# Altium schematic sheet 08-MCU.SchDoc
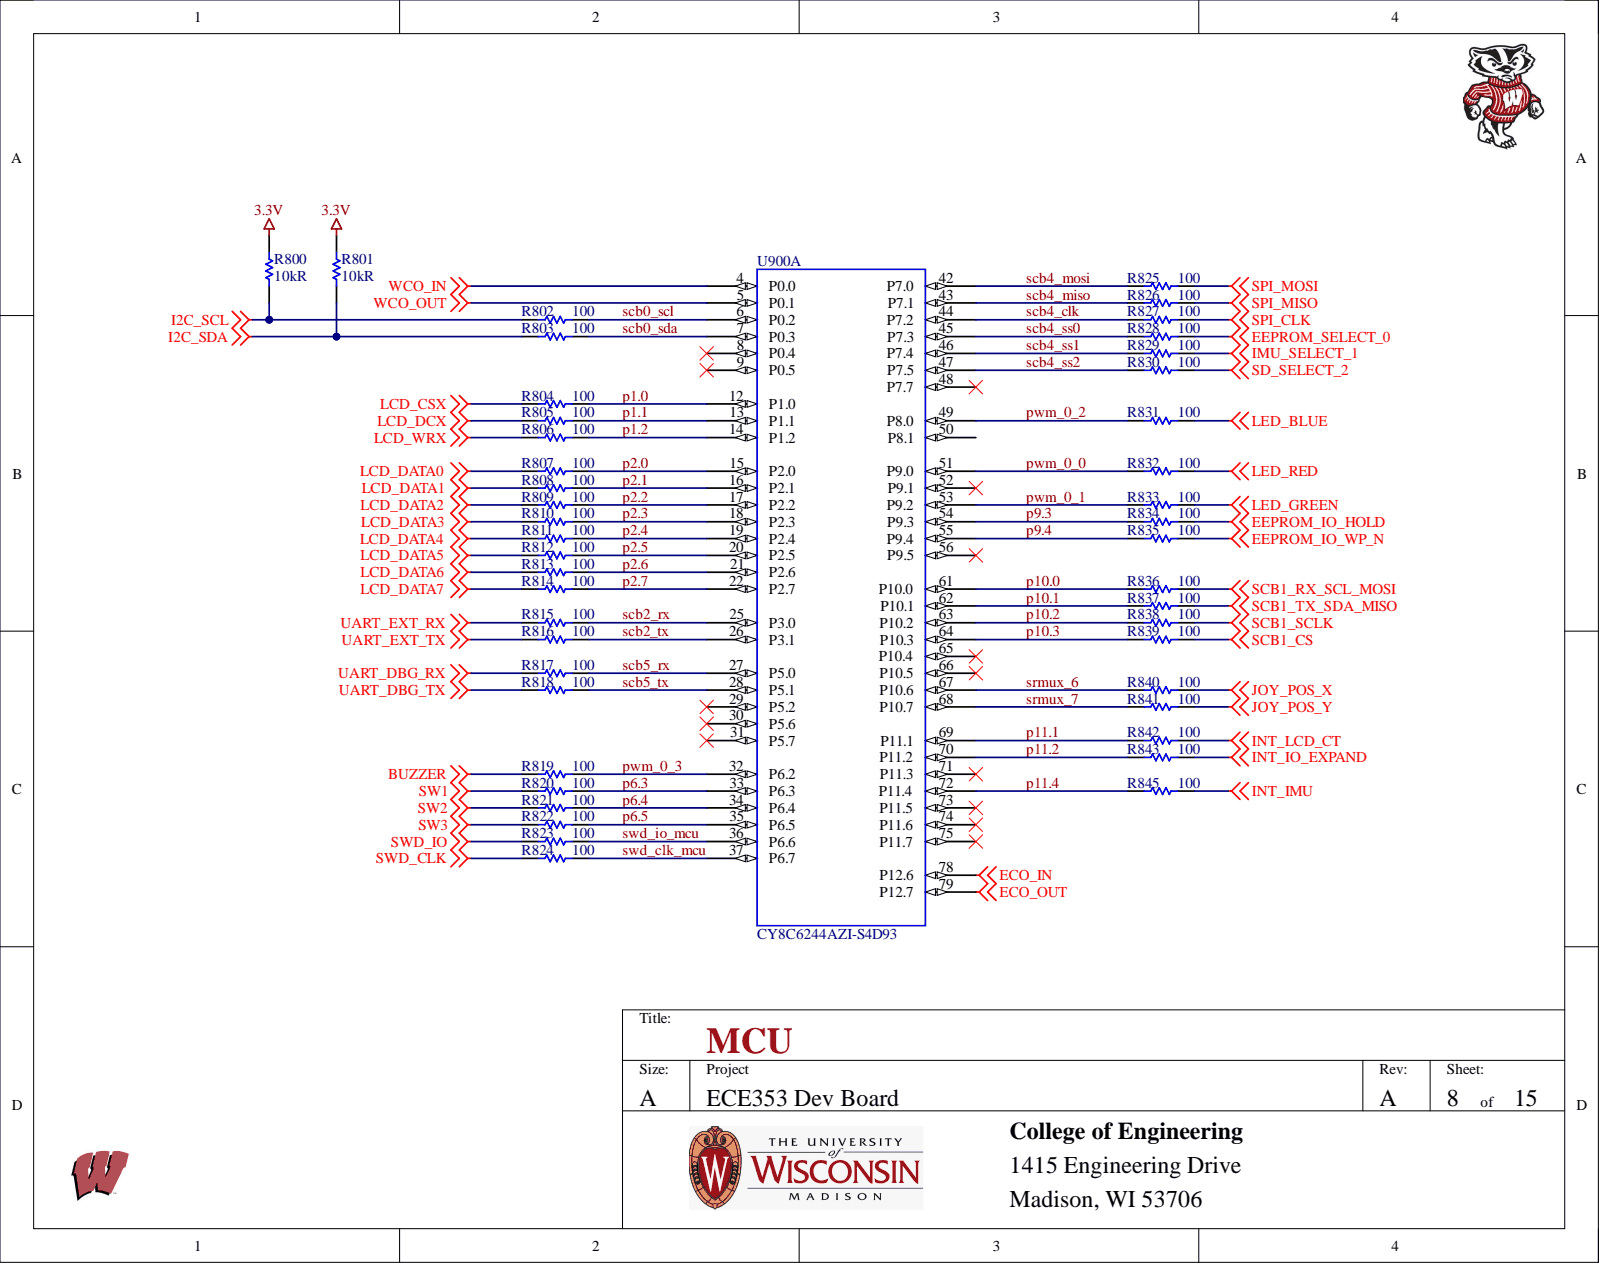
[diagram p0: 08-MCU.SchDoc]

## Parts
R800  Resistance: 10kR  [RESC2013X60X35NL10T20]  (hidden comment: RC0805FR-0710KL)
R801  Resistance: 10kR  [RESC2013X60X35NL10T20]  (hidden comment: RC0805FR-0710KL)
R802  RC0805FR-07100RL  [RESC2013X60X35ML10T20]  (value not drawn)
R803  RC0805FR-07100RL  [RESC2013X60X35ML10T20]  (value not drawn)
R804  RC0805FR-07100RL  [RESC2013X60X35ML10T20]  (value not drawn)
R805  RC0805FR-07100RL  [RESC2013X60X35ML10T20]  (value not drawn)
R806  RC0805FR-07100RL  [RESC2013X60X35ML10T20]  (value not drawn)
R807  RC0805FR-07100RL  [RESC2013X60X35ML10T20]  (value not drawn)
R808  RC0805FR-07100RL  [RESC2013X60X35ML10T20]  (value not drawn)
R809  RC0805FR-07100RL  [RESC2013X60X35ML10T20]  (value not drawn)
R810  RC0805FR-07100RL  [RESC2013X60X35ML10T20]  (value not drawn)
R811  RC0805FR-07100RL  [RESC2013X60X35ML10T20]  (value not drawn)
R812  RC0805FR-07100RL  [RESC2013X60X35ML10T20]  (value not drawn)
R813  RC0805FR-07100RL  [RESC2013X60X35ML10T20]  (value not drawn)
R814  RC0805FR-07100RL  [RESC2013X60X35ML10T20]  (value not drawn)
R815  RC0805FR-07100RL  [RESC2013X60X35ML10T20]  (value not drawn)
R816  RC0805FR-07100RL  [RESC2013X60X35ML10T20]  (value not drawn)
R817  RC0805FR-07100RL  [RESC2013X60X35ML10T20]  (value not drawn)
R818  RC0805FR-07100RL  [RESC2013X60X35ML10T20]  (value not drawn)
R819  RC0805FR-07100RL  [RESC2013X60X35ML10T20]  (value not drawn)
R820  RC0805FR-07100RL  [RESC2013X60X35ML10T20]  (value not drawn)
R821  RC0805FR-07100RL  [RESC2013X60X35ML10T20]  (value not drawn)
R822  RC0805FR-07100RL  [RESC2013X60X35ML10T20]  (value not drawn)
R823  RC0805FR-07100RL  [RESC2013X60X35ML10T20]  (value not drawn)
R824  RC0805FR-07100RL  [RESC2013X60X35ML10T20]  (value not drawn)
R825  RC0805FR-07100RL  [RESC2013X60X35ML10T20]  (value not drawn)
R826  RC0805FR-07100RL  [RESC2013X60X35ML10T20]  (value not drawn)
R827  RC0805FR-07100RL  [RESC2013X60X35ML10T20]  (value not drawn)
R828  RC0805FR-07100RL  [RESC2013X60X35ML10T20]  (value not drawn)
R829  RC0805FR-07100RL  [RESC2013X60X35ML10T20]  (value not drawn)
R830  RC0805FR-07100RL  [RESC2013X60X35ML10T20]  (value not drawn)
R831  RC0805FR-07100RL  [RESC2013X60X35ML10T20]  (value not drawn)
R832  RC0805FR-07100RL  [RESC2013X60X35ML10T20]  (value not drawn)
R833  RC0805FR-07100RL  [RESC2013X60X35ML10T20]  (value not drawn)
R834  RC0805FR-07100RL  [RESC2013X60X35ML10T20]  (value not drawn)
R835  RC0805FR-07100RL  [RESC2013X60X35ML10T20]  (value not drawn)
R836  RC0805FR-07100RL  [RESC2013X60X35ML10T20]  (value not drawn)
R837  RC0805FR-07100RL  [RESC2013X60X35ML10T20]  (value not drawn)
R838  RC0805FR-07100RL  [RESC2013X60X35ML10T20]  (value not drawn)
R839  RC0805FR-07100RL  [RESC2013X60X35ML10T20]  (value not drawn)
R840  RC0805FR-07100RL  [RESC2013X60X35ML10T20]  (value not drawn)
R841  RC0805FR-07100RL  [RESC2013X60X35ML10T20]  (value not drawn)
R842  RC0805FR-07100RL  [RESC2013X60X35ML10T20]  (value not drawn)
R843  RC0805FR-07100RL  [RESC2013X60X35ML10T20]  (value not drawn)
R845  RC0805FR-07100RL  [RESC2013X60X35ML10T20]  (value not drawn)
U900  CY8C6244AZI-S4D93  [CY8C6144AZI-S4F93]  (part A)

## Nets
3.3V: R800.1 R801.1
BUZZER: R819.1
ECO_IN: U900.78(P12.6)
ECO_OUT: U900.79(P12.7)
EEPROM_IO_HOLD: R834.2
EEPROM_IO_WP_N: R835.2
EEPROM_SELECT_0: R828.2
I2C_SCL: R800.2 R802.1
I2C_SDA: R801.2 R803.1
IMU_SELECT_1: R829.2
INT_IMU: R845.2
INT_IO_EXPAND: R843.2
INT_LCD_CT: R842.2
JOY_POS_X: R840.2
JOY_POS_Y: R841.2
LCD_CSX: R804.1
LCD_DATA0: R807.1
LCD_DATA1: R808.1
LCD_DATA2: R809.1
LCD_DATA3: R810.1
LCD_DATA4: R811.1
LCD_DATA5: R812.1
LCD_DATA6: R813.1
LCD_DATA7: R814.1
LCD_DCX: R805.1
LCD_WRX: R806.1
LED_BLUE: R831.2
LED_GREEN: R833.2
LED_RED: R832.2
SD_SELECT_2: R830.2
SPI_CLK: R827.2
SPI_MISO: R826.2
SPI_MOSI: R825.2
SW1: R820.1
SW2: R821.1
SW3: R822.1
SWD_CLK: R824.1
SWD_IO: R823.1
UART_DBG_RX: R817.1
UART_DBG_TX: R818.1
UART_EXT_RX: R815.1
UART_EXT_TX: R816.1
WCO_IN: U900.4(P0.0)
WCO_OUT: U900.5(P0.1)
p1.0: R804.2 U900.12(P1.0)
p1.1: R805.2 U900.13(P1.1)
p1.2: R806.2 U900.14(P1.2)
p2.0: R807.2 U900.15(P2.0)
p2.1: R808.2 U900.16(P2.1)
p2.2: R809.2 U900.17(P2.2)
p2.3: R810.2 U900.18(P2.3)
p2.4: R811.2 U900.19(P2.4)
p2.5: R812.2 U900.20(P2.5)
p2.6: R813.2 U900.21(P2.6)
p2.7: R814.2 U900.22(P2.7)
p6.3: R820.2 U900.33(P6.3)
p6.4: R821.2 U900.34(P6.4)
p6.5: R822.2 U900.35(P6.5)
p9.3: R834.1 U900.54(P9.3)
p9.4: R835.1 U900.55(P9.4)
p10.0: R836.1 U900.61(P10.0)
p10.1: R837.1 U900.62(P10.1)
p10.2: R838.1 U900.63(P10.2)
p10.3: R839.1 U900.64(P10.3)
p11.1: R842.1 U900.69(P11.1)
p11.2: R843.1 U900.70(P11.2)
p11.4: R845.1 U900.72(P11.4)
pwm_0_0: R832.1 U900.51(P9.0)
pwm_0_1: R833.1 U900.53(P9.2)
pwm_0_2: R831.1 U900.49(P8.0)
pwm_0_3: R819.2 U900.32(P6.2)
scb0_scl: R802.2 R836.2 R837.2 R838.2 R839.2 U900.6(P0.2)
scb0_sda: R803.2 U900.7(P0.3)
scb2_rx: R815.2 U900.25(P3.0)
scb2_tx: R816.2 U900.26(P3.1)
scb4_clk: R827.1 U900.44(P7.2)
scb4_miso: R826.1 U900.43(P7.1)
scb4_mosi: R825.1 U900.42(P7.0)
scb4_ss0: R828.1 U900.45(P7.3)
scb4_ss1: R829.1 U900.46(P7.4)
scb4_ss2: R830.1 U900.47(P7.5)
scb5_rx: R817.2 U900.27(P5.0)
scb5_tx: R818.2 U900.28(P5.1)
srmux_6: R840.1 U900.67(P10.6)
srmux_7: R841.1 U900.68(P10.7)
swd_clk_mcu: R824.2 U900.37(P6.7)
swd_io_mcu: R823.2 U900.36(P6.6)

Not connected: U900 8(P0.4) 9(P0.5) 29(P5.2) 30(P5.6) 31(P5.7) 48(P7.7) 50(P8.1) 52(P9.1) 56(P9.5) 65(P10.4) 66(P10.5) 71(P11.3) 73(P11.5) 74(P11.6) 75(P11.7)

## Library data of the parts
R800 R801 = CMP-1659-00013-4: Chip Resistor, 10 KOhm, +/- 1%, 0.125 W, -55 to 155 degC, 0805 (2012 Metric), RoHS, Tape and Reel; Manufacturer: Yageo; Max Power Dissipation: 125mW; Temperature Coefficient: 100ppm/°C; Voltage Rating: 150 V; Case Code (Imperial): 0805; Case Code (Metric): 2012; Case/Package: 0805; Category: Chip SMD Resistors; Component Kind: Standard; ComponentLink1Description: Manufacturer URL; ComponentLink1URL: http://www.yageo.com/; ComponentLink2Description: Datasheet; ComponentLink2URL: http://www.yageo.com/documents/recent/PYu-RC_Group_51_RoHS_L_04.pdf; Construction: Rectangular; DatasheetVersion: Rev. 4, 02/2016; Depth: 1.25mm; Features: Moisture Resistant; Height: 0.5 mm; Lead Free: Lead Free; Length: 2 mm; Library Name: Altium Content Vault; Manufacturer 1: Yageo; Manufacturer Part Number 1: RC0805FR-0710KL; Max Operating Temperature: 155 degC; Military Standard: Not; Min Operating Temperature: -55 degC; Mount: Surface Mount; Mounting Technology: Surface Mount; Number of Terminations: 2; Package Quantity: 5000; PackageDescription: 2-Pin Surface Mounted Device, Body 2 x 1.25 mm; PackageReference: 0805; Packaging: Tape and Reel; Pins: 2; Power Rating: 125mW; Pricing 1: 1=0.10000, 10=0.03700, 100=0.01520, 1000=0.00682, 2500=0.00592 (USD); REACH SVHC: No SVHC; Resistance Tolerance: 1%; Resistor Type: Thick Film; RoHS: Yes; RoHS Compliant: Yes; RoHSCompliant: true; Series: RC; Size Code: 0805; Stock 1: 12202331; Supplier 1: Digi-Key; Supplier Part Number 1: 311-10.0KCRCT-ND; Technology: THICK FILM; Termination: SMD/SMT; Tolerance: 1%; Width: 0.049inch
R802-R843 R845 = CMP-2100-03669-1: Chip Resistor, 100 Ohm, +/-1%, 0.125 W, -55 to 155 degC, 0805 (2012 Metric), RoHS, Tape and Reel; Manufacturer: Yageo; Application(s): HIGH RELIABILITY; Max Power Dissipation: 125mW; Resistance: 100; Temperature Coefficient: 100ppm/degreesC; Tolerance: 1%; Voltage Rating: 150V; Case Code (Imperial): 0805; Case Code (Metric): 2012; Case/Package: 0805; Category: Chip SMD Resistors; Component Kind: Standard; ComponentLink1Description: https://datasheet.ciiva.com/7941/1697719-7941680.pdf?src-supplier=Element14; ComponentLink1URL: https://datasheet.ciiva.com/7941/1697719-7941680.pdf?src-supplier=Element14; ComponentLink2Description: https://datasheet.ciiva.com/11808/0900766b8106beab-11808877.pdf?src-supplier=Rs; ComponentLink2URL: https://datasheet.ciiva.com/11808/0900766b8106beab-11808877.pdf?src-supplier=Rs; ComponentLink3Description: https://datasheet.ciiva.com/7941/1686128-7941679.pdf?src-supplier=Element14; ComponentLink3URL: https://datasheet.ciiva.com/7941/1686128-7941679.pdf?src-supplier=Element14; ComponentLink4Description: https://datasheet.ciiva.com/7660/38627-7660797.pdf?src-supplier=Element14; ComponentLink4URL: https://datasheet.ciiva.com/7660/38627-7660797.pdf?src-supplier=Element14; ComponentLink5Description: https://datasheet.ciiva.com/22747/444164-22747535.pdf?src-supplier=Element14; ComponentLink5URL: https://datasheet.ciiva.com/22747/444164-22747535.pdf?src-supplier=Element14; ComponentLink6Description: https://datasheet.ciiva.com/46447/444164-46447562.pdf?src-supplier=Element14; ComponentLink6URL: https://datasheet.ciiva.com/46447/444164-46447562.pdf?src-supplier=Element14; ComponentLink7Description: https://datasheet.ciiva.com/2456/pyu-r-int-thick-7-2456988.pdf?src-supplier=Digi-Key; ComponentLink7URL: https://datasheet.ciiva.com/2456/pyu-r-int-thick-7-2456988.pdf?src-supplier=Digi-Key; ComponentLink8Description: https://datasheet.ciiva.com/2456/pyu-r-int-thick-7-2456989.pdf?src-supplier=Digi-Key; ComponentLink8URL: https://datasheet.ciiva.com/2456/pyu-r-int-thick-7-2456989.pdf?src-supplier=Digi-Key; ComponentLink9Description: https://datasheet.ciiva.com/2456/pyu-r-int-thick-7-2456987.pdf?src-supplier=Digi-Key; ComponentLink9URL: https://datasheet.ciiva.com/2456/pyu-r-int-thick-7-2456987.pdf?src-supplier=Digi-Key; ComponentLink10Description: https://datasheet.ciiva.com/17082/rc0805-17082697.pdf?src-supplier=Future+Electronics; ComponentLink10URL: https://datasheet.ciiva.com/17082/rc0805-17082697.pdf?src-supplier=Future+Electronics; Composition: ThickFilm; Datasheet URL: http://www.yageo.com/NewPortal/yageodocoutput?fileName=/pdf/R-Chip/PYu-RC_Group_51_RoHS_L_5.pdf; Datasheet Version: Rev. 5, 10/2016; Depth: 1.25mm; Features: Moisture Resistant; Height: 0.024inch; Lead Free: LeadFree; Lead Pitch: 0.4mm; Length: 2mm; Library Name: Altium Content Vault; Manufacturer 1: Yageo; Manufacturer Part Number 1: RC0805FR-07100RL; Manufacturer URL: http://www.yageo.com/; Max Operating Temperature: 155degC; Military Standard: Not; Min Operating Temperature: -55degC; Number of Pins: 2; Number of Terminations: 2; Package Description: 2-Pin Surface Mounted Device, Body 2 x 1.25 mm; Package Quantity: 1; Package Reference: 0805; Packaging: TapeandReel; Power Rating: 125mW; Pricing 1: 1=0.10000, 10=0.03300, 100=0.01330, 1000=0.00600, 2500=0.00520 (USD); REACH SVHC: Unknown; Resistor Type: Thick Film; RoHS: Yes; RoHS Compliant: Yes; RoHSCompliant: true; Series: RC; Stock 1: 3383515; Supplier 1: Digi-Key; Supplier Part Number 1: 311-100CRCT-ND; Technology: THICK FILM; Termination: SMD/SMT; Type: General Purpose; Voltage Rating (DC): 150V; Weight: 0.000917oz; Width: 0.049inch
U900 = CY8C6144AZI-S4F93: Mcu, 32 Bit, 150MHZ, TQFP-80;; Manufacturer 1: Infineon; Category: Microcontrollers; Component Kind: Standard; Library Name: ece353-2022.SchLib; Manufacturer Part Number 1: CY8C6244AZI-S4D93; Pricing 1: 1=9.72000, 10=8.77700, 25=8.36920, 119=7.26689, 238=6.94025, 476=6.32788, 952=6.21250 (USD); RoHS: No; Stock 1: 859; Supplier 1: Digi-Key; Supplier Part Number 1: 448-CY8C6244AZI-S4D93-ND
